# Altium schematic sheet Sheet2.SchDoc
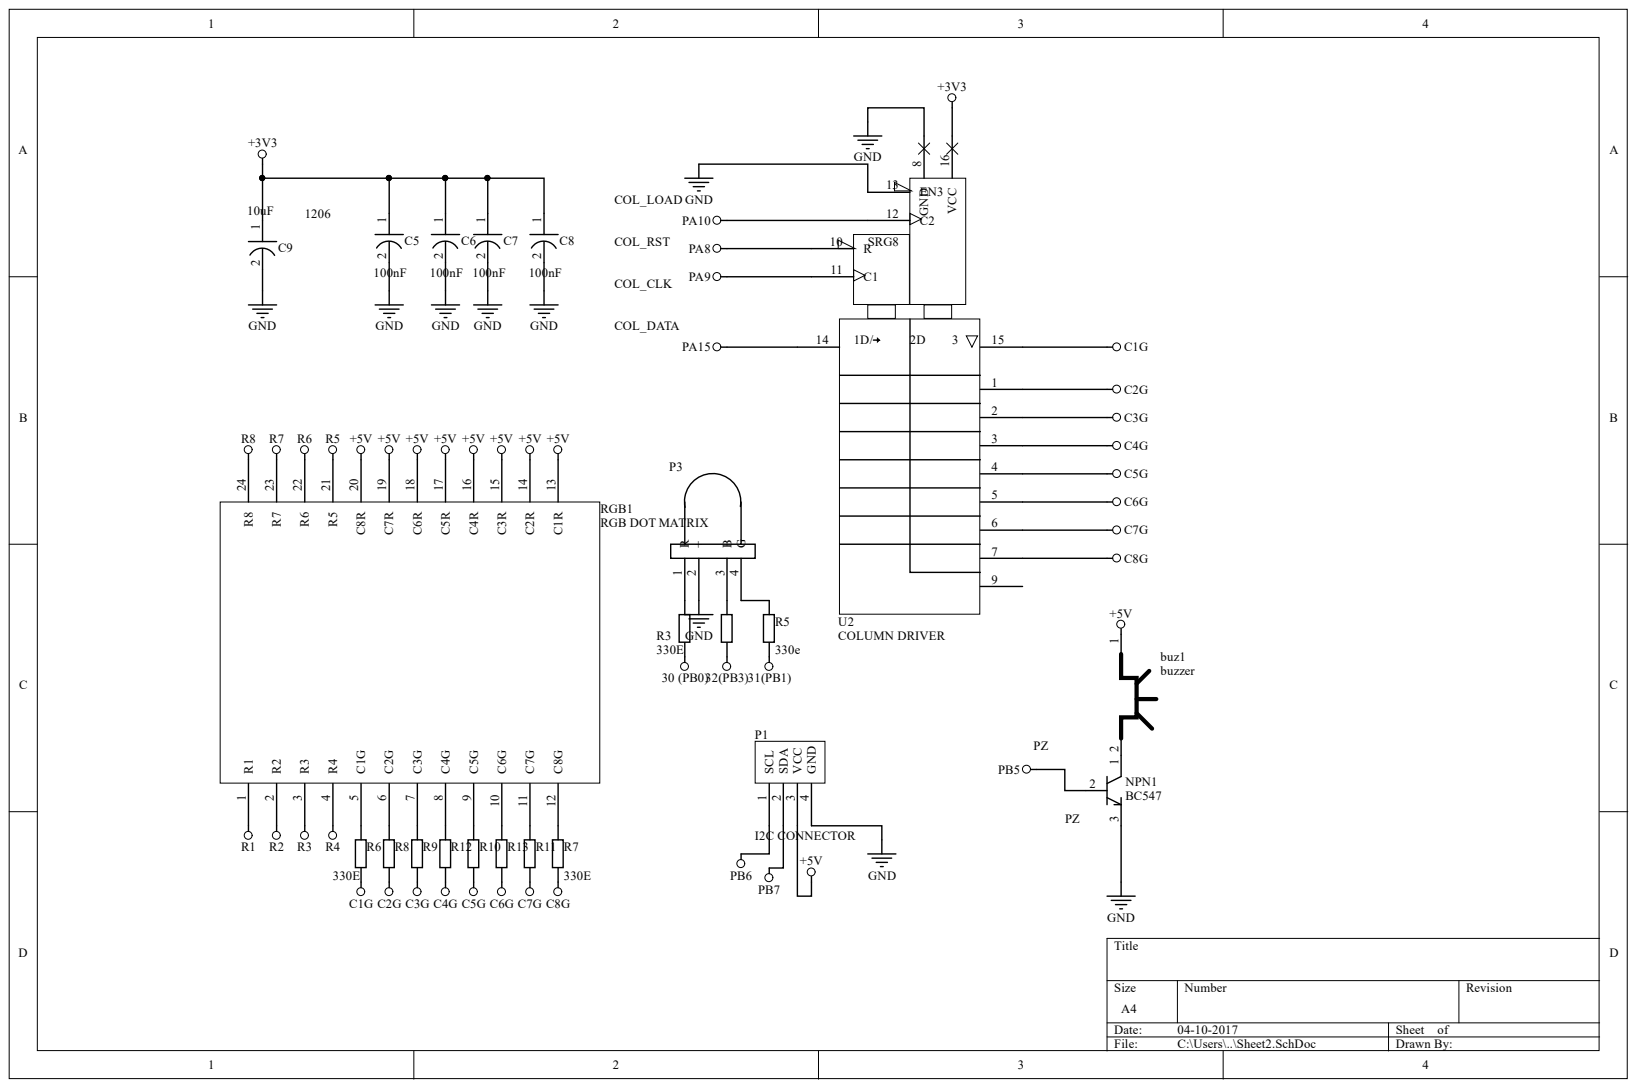
[diagram p0: Sheet2.SchDoc]

## Parts
buz1  buzzer  [buzzer 0.2]
C5  CAP_Elec  [CAP_Electrolytic]  (value not drawn)
C6  CAP_Elec  [CAP_Electrolytic]  (value not drawn)
C7  CAP_Elec  [CAP_Electrolytic]  (value not drawn)
C8  CAP_Elec  [CAP_Electrolytic]  (value not drawn)
C9  CAP_Elec  [CAP_Electrolytic]  (value not drawn)
NPN1  BC547  [TO92-100]
P1  I2C CONNECTOR  [I2C Connector]
P3  (no value)  [RGB LED_0.1]
R3  value 330E  [AXIAL-0.4]  (hidden comment: Res2)
R4  Res2  [AXIAL-0.4]  (value not drawn)
R5  value 330e  [AXIAL-0.4]  (hidden comment: Res2)
R6  value 330E  [AXIAL-0.4]  (hidden comment: Res2)
R7  value 330E  [AXIAL-0.4]  (hidden comment: Res2)
R8  Res2  [AXIAL-0.4]  (value not drawn)
R9  Res2  [AXIAL-0.4]  (value not drawn)
R10  Res2  [AXIAL-0.4]  (value not drawn)
R11  Res2  [AXIAL-0.4]  (value not drawn)
R12  Res2  [AXIAL-0.4]  (value not drawn)
R13  Res2  [AXIAL-0.4]  (value not drawn)
RGB1  RGB DOT MATRIX  [RGB DOT Matrix]
U2  COLUMN DRIVER  [DIP16_300]

## Nets
30 (PB0): R3.1
31(PB1): R5.1
32(PB3): R4.1
+3V3: C5.1 C6.1 C7.1 C8.1 C9.1 U2.16(VCC)
+5V: buz1.1 P1.3(VCC) RGB1.13(C1R) RGB1.14(C2R) RGB1.15(C3R) RGB1.16(C4R) RGB1.17(C5R) RGB1.18(C6R) RGB1.19(C7R) RGB1.20(C8R)
C1G: R6.1 U2.15
C2G: R8.1 U2.1
C3G: R9.1 U2.2
C4G: R12.1 U2.3
C5G: R10.1 U2.4
C6G: R13.1 U2.5
C7G: R11.1 U2.6
C8G: R7.1 U2.7
GND: C5.2 C6.2 C7.2 C8.2 C9.2 NPN1.3 P1.4(GND) P3.2(+) U2.8(GND) U2.13(EN3)
PA8: U2.10(R)
PA9: U2.11(C1)
PA10: U2.12(C2)
PA15: U2.14
PB5: NPN1.2
PB6: P1.1(SCL)
PB7: P1.2(SDA)
R1: RGB1.1(R1)
R2: RGB1.2(R2)
R3: RGB1.3(R3)
R4: RGB1.4(R4)
R5: RGB1.21(R5)
R6: RGB1.22(R6)
R7: RGB1.23(R7)
R8: RGB1.24(R8)
Netbuz1_2: buz1.2 NPN1.1
NetP3_1: P3.1(R) R3.2
NetP3_3: P3.3(B) R4.2
NetP3_4: P3.4(G) R5.2
NetR6_2: R6.2 RGB1.5(C1G)
NetR7_2: R7.2 RGB1.12(C8G)
NetR8_2: R8.2 RGB1.6(C2G)
NetR9_2: R9.2 RGB1.7(C3G)
NetR10_2: R10.2 RGB1.9(C5G)
NetR11_2: R11.2 RGB1.11(C7G)
NetR12_2: R12.2 RGB1.8(C4G)
NetR13_2: R13.2 RGB1.10(C6G)
1206:
COL_CLK:
COL_DATA:
COL_LOAD:
COL_RST:
PZ:

Not connected: U2 9

## Library data of the parts
C5-C9 = CAP_Elec: CAP_ELECTROLYTIC; Component Kind: Standard; Library Name: Integrated_Library.IntLib
  C5-C8: : 100nF
  C9: : 10uF
P1 = I2C CONNECTOR: Header, 2-Pin; Component Kind: Standard; LatestRevisionDate: 17-Jul-2002; LatestRevisionNote: Re-released for DXP Platform.; Library Name: Schlib1.SchLib; Publisher: Altium Limited
P3 = RGB: Header, 2-Pin; Component Kind: Standard; LatestRevisionDate: 17-Jul-2002; LatestRevisionNote: Re-released for DXP Platform.; Library Name: Schlib1.SchLib; Publisher: Altium Limited
R3 R5-R7 = Res2: Resistor; Component Kind: Standard; LatestRevisionDate: 17-Jul-2002; LatestRevisionNote: Re-released for DXP Platform.; Library Name: Miscellaneous Devices.IntLib; PackageDescription: Axial Device, Thru-Hole; 2 Leads; 0.4 in Pin Spacing; PackageReference: AXIAL-0.4; Published: 8-Jun-2000; Signal Integrity: Res; Simulation: RESISTOR; Publisher: Altium Limited
R4 = Res2: Resistor; Value: 330E; Component Kind: Standard; LatestRevisionDate: 17-Jul-2002; LatestRevisionNote: Re-released for DXP Platform.; Library Name: Miscellaneous Devices.IntLib; PackageDescription: Axial Device, Thru-Hole; 2 Leads; 0.4 in Pin Spacing; PackageReference: AXIAL-0.4; Published: 8-Jun-2000; Signal Integrity: Res; Simulation: RESISTOR; Publisher: Altium Limited
R8-R13 = Res2: Resistor; Value: 56E; Component Kind: Standard; LatestRevisionDate: 17-Jul-2002; LatestRevisionNote: Re-released for DXP Platform.; Library Name: Miscellaneous Devices.IntLib; PackageDescription: Axial Device, Thru-Hole; 2 Leads; 0.4 in Pin Spacing; PackageReference: AXIAL-0.4; Published: 8-Jun-2000; Signal Integrity: Res; Simulation: RESISTOR; Publisher: Altium Limited
RGB1 = LED_RGB_MATRIX1: Dot Matrix; Component Kind: Standard; LatestRevisionDate: 17-Jul-2002; LatestRevisionNote: Re-released for DXP Platform.; Library Name: Schlib1.SchLib; Publisher: Altium Limited
U2 = 74HC595: Component Kind: Standard; Description: COLUMN DRIVER; LatestRevisionDate: 17-Jul-2002; LatestRevisionNote: Re-released for DXP Platform.; Library Name: Schlib1.SchLib; Publisher: Altium Limited
buz1 = buzzer: Component Kind: Standard; Library Name: Integrated_Library1.IntLib
NPN1 = BC547: Component Kind: Standard; Description: BC547; Library Name: Integrated_Library.IntLib
